# Altium schematic sheet Power over Ethernet.SchDoc
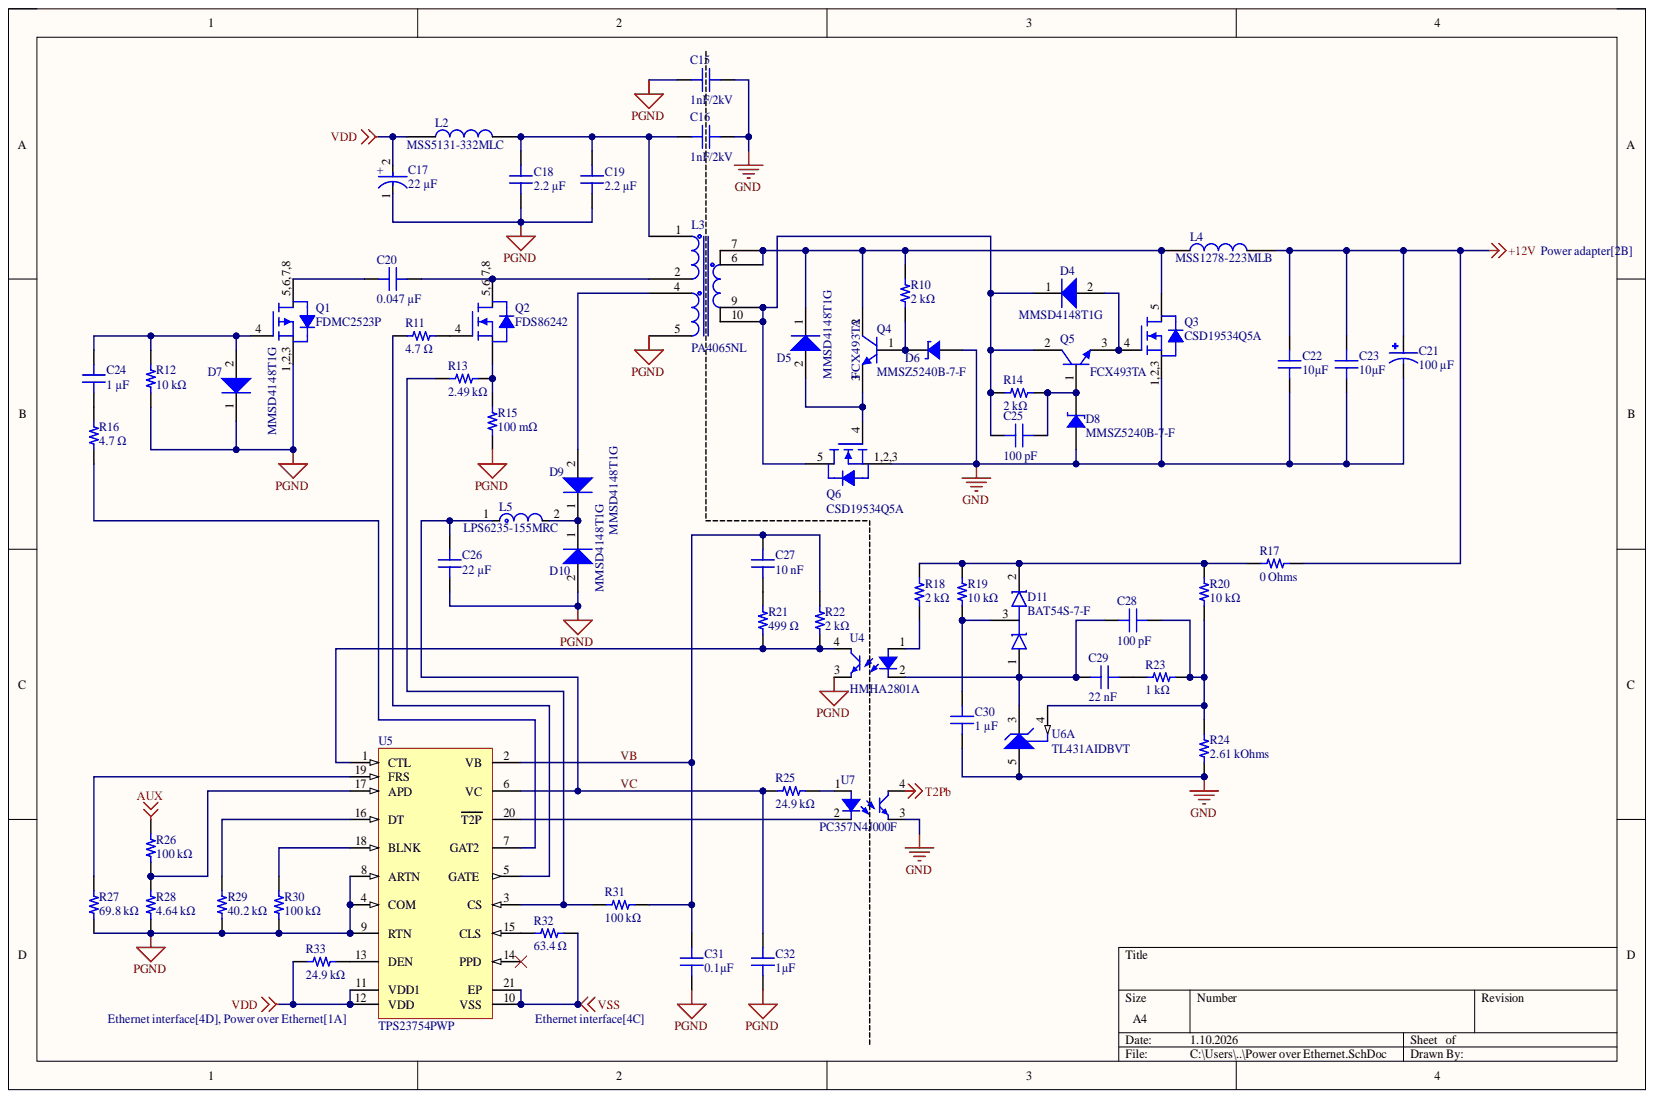
[diagram p0: Power over Ethernet.SchDoc]

## Parts
C15  1nF/2kV  [CAP 1206_3216 - 0.8MM]
C16  1nF/2kV  [CAP 1206_3216 - 0.8MM]
C17  22 µF  [FP-FKE-MFG]
C18  2.2 µF  [CAP 1210_3225 - 1MM]
C19  2.2 µF  [CAP 1210_3225 - 1MM]
C20  0.047 µF  [CAP 1206_3216 - 0.8MM]
C21  100 µF  [CAP_EEEFC1E101P]
C22  10µF  [CAP 1210_3225 - 2.8MM]
C23  10µF  [CAP 1210_3225 - 2.8MM]
C24  1 µF  [CAP 0805_2012]
C25  100 pF  [CAP 0603_1608]
C26  22 µF  [CAP 1210_3225 - 1MM]
C27  Capacitance: 10 nF  [CAP 0603_1608]  (hidden comment: 10000 pF)
C28  100 pF  [CAP 0603_1608]
C29  Capacitance: 22 nF  [CAP 0603_1608]  (hidden comment: 0.022 µF)
C30  1 µF  [CAP 0805_2012]
C31  0.1µF  [CAP 0805_2012]
C32  1µF  [CAP 0805_2012]
D4  MMSD4148T1G  [ONSC-SOD-123-2-425-04_V]
D5  MMSD4148T1G  [ONSC-SOD-123-2-425-04_V]
D6  MMSZ5240B-7-F  [SOD-123]
D7  MMSD4148T1G  [ONSC-SOD-123-2-425-04_V]
D8  MMSZ5240B-7-F  [SOD-123]
D9  MMSD4148T1G  [ONSC-SOD-123-2-425-04_V]
D10  MMSD4148T1G  [ONSC-SOD-123-2-425-04_V]
D11  BAT54S-7-F  [SOT96P240X115-3N]
L2  MSS5131-332MLC  [MSS5131332MLC]
L3  PA4065NL  [PA4065NL]
L4  MSS1278-223MLB  [INDPM120120X805N]
L5  LPS6235-155MRC  [FP-LPS6235-MFG]
Q1  FDMC2523P  [FDMC_2523P_ONS]
Q2  FDS86242  [SOIC127P600X175-8N]
Q3  CSD19534Q5A  [TRANS_CSD19534Q5A]
Q4  FCX493TA  [FCX493TA_DIO]
Q5  FCX493TA  [FCX493TA_DIO]
Q6  CSD19534Q5A  [TRANS_CSD19534Q5A]
R10  2 kΩ  [RES 1206_3216]
R11  4.7 Ω  [RES 0603_1608]
R12  10 kΩ  [RES 0603_1608]
R13  2.49 kΩ  [RES 0603_1608]
R14  2 kΩ  [RES 1206_3216]
R15  100 mΩ  [RES 1210_3225]
R16  4.7 Ω  [RES 0603_1608]
R17  0 Ohms  [RES 0603_1608]
R18  2 kΩ  [RES 0603_1608]
R19  10 kΩ  [RES 0603_1608]
R20  10 kΩ  [RES 0603_1608]
R21  499 Ω  [RES 0603_1608]
R22  2 kΩ  [RES 0603_1608]
R23  1 kΩ  [RES 0603_1608]
R24  2.61 kOhms  [RES 0603_1608]
R25  24.9 kΩ  [RES 0603_1608]
R26  100 kΩ  [RES 0603_1608]
R27  69.8 kΩ  [RES 0603_1608]
R28  4.64 kΩ  [YAGEO RES 0603_1608]
R29  40.2 kΩ  [YAGEO RES 0603_1608]
R30  100 kΩ  [RES 0603_1608]
R31  100 kΩ  [RES 0603_1608]
R32  63.4 Ω  [KOA RES RK73 RN73 0603_1608]
R33  24.9 kΩ  [RES 0603_1608]
U4  HMHA2801A  [SOIC127P680X239-4N]
U5  TPS23754PWP  [PWP0020D_M]
U6  TL431AIDBVT  [DBV0005A_N]  (part A)
U7  PC357N4J000F  [SOP254P675X300-4N]

## Nets
+12V: C21.1 C22.2 C23.2 L4.2 R17.2
AUX: R26.2
GND: C15.1 C16.1 C21.2 C22.1 C23.1 C30.1 D6.1 D8.1 Q3.1,2,3 Q6.1,2,3 R24.1 U6.5 U7.3
PGND: C15.2 C17.1 C18.1 C19.1 C26.1 C31.1 C32.2 D7.1 D10.2 L3.5 Q1.1,2,3 R12.1 R15.1 R27.1 R28.1 R29.1 R30.1 U4.3 U5.4(COM) U5.8(ARTN) U5.9(RTN)
T2Pb: U7.4
VDD: C17.2 L2.1 R33.1 U5.11(VDD1) U5.12(VDD)
VSS: R32.1 U5.10(VSS) U5.21(EP)
VB: C27.1 C31.2 R22.1 R31.2 U5.2(VB)
VC: C26.2 C32.1 L5.1 R25.1 U5.6(VC)
NetC16_2: C16.2 C18.2 C19.2 L2.2 L3.1
NetC20_1: C20.1 Q1.5,6,7,8
NetC20_2: C20.2 L3.2 Q2.5,6,7,8
NetC24_1: C24.1 R16.2
NetC24_2: C24.2 D7.2 Q1.4 R12.2
NetC25_1: C25.1 D4.1 L3.9 L3.10 Q5.2 Q6.5 R14.2
NetC25_2: C25.2 D8.2 Q5.1 R14.1
NetC27_2: C27.2 R21.1
NetC28_1: C28.1 C29.1 D11.1 U4.2 U6.3
NetC28_2: C28.2 R20.1 R23.2 R24.2 U6.4
NetC29_2: C29.2 R23.1
NetC30_2: C30.2 D11.3 R19.1
NetD4_2: D4.2 Q3.4 Q5.3
NetD5_1: D5.1 L3.6 L3.7 L4.1 Q3.5 Q4.2 R10.2
NetD5_2: D5.2 Q4.3 Q6.4
NetD6_2: D6.2 Q4.1 R10.1
NetD9_1: D9.1 D10.1 L5.2
NetD9_2: D9.2 L3.4
NetD11_2: D11.2 R17.1 R18.1 R19.2 R20.2
NetQ2_1,2,3: Q2.1,2,3 R13.2 R15.2
NetQ2_4: Q2.4 R11.2
NetR11_1: R11.1 U5.5(GATE)
NetR13_1: R13.1 R31.1 U5.3(CS)
NetR16_1: R16.1 U5.7(GAT2)
NetR18_2: R18.2 U4.1
NetR21_2: R21.2 R22.2 U4.4 U5.1(CTL)
NetR25_2: R25.2 U7.1
NetR26_1: R26.1 R28.2 U5.17(APD)
NetR27_2: R27.2 U5.19(FRS)
NetR29_2: R29.2 U5.16(DT)
NetR30_2: R30.2 U5.18(BLNK)
NetR32_2: R32.2 U5.15(CLS)
NetR33_2: R33.2 U5.13(DEN)
NetU5_20: U5.20(T2P) U7.2

Not connected: U5 14(PPD)

## Library data of the parts
C15 C16 = 1206B102K202NT: CAP CER 1000PF 2KV X7R 1206; Database Table Name: Capacitors - Ceramic - 1206; Capacitance: 1000 pF; Component Kind: Standard; Library Name: Celestial Altium Library.DbLib; Part Number: 1206B102K202NT; Supplier 1: Arrow Electronics; Supplier Part Number 1: 1206B102K202NT
C17 = CMP-05427-002164-1: CAP ALUM 22UF 20% 63V SMD; Dielectric Material: Aluminium; ESR (Equivalent Series Resistance): 1.2R; Leakage Current: 3uA; Life (Hours): 2000Hour; Ripple Current: 120mA; Capacitance: 22 uF; Case/Package: Radial; Component Kind: Standard; Construction: Cylindrical; Contact Plating: Tin; Diameter: 8mm; Height: 6.2mm; Height - Seated (Max): 0.244inch; Impedance: 1.2R; Lead Free: Lead Free; Length: 6.2mm; Library Name: Altium Content Vault; Material: Aluminium; Max Operating Temperature: 105°C; Min Operating Temperature: -55°C; Mount: Surface Mount; Package Quantity: 1000; Packaging: Tape and Reel; Radiation Hardening: No; Ratings: AEC-Q200; Reach SVHC Compliant: Yes; Ripple Current (AC): 120mA; RoHS Compliant: Yes; Series: FK; Size: 6.2mm; Termination: SMD/SMT; Tolerance: 20%; Value: 22 µF; Voltage: 63V; Voltage Rating: 63V; Voltage Rating (DC): 63V; Width: 8.3mm
C18 C19 = CL32B225KCJSNNE: CAP CER 2.2UF 100V X7R 1210; manufacturer: Samsung Electro-Mechanics; Database Table Name: Capacitors - Ceramic - 1210; Tolerance: ±10%; Applications: General Purpose; Capacitance: 2.2 µF; Component Kind: Standard; componentlink1description: Datasheet; componentlink1url: http://www.samsungsem.com/kr/support/product-search/mlcc/CL32B225KCJSNNE .jsp; componentlink2description: DigiKey Link; componentlink2url: https://www.digikey.com/en/products/detail/samsung-electro-mechanics/CL32B225KCJSNNE/3889020; Device Package: 1210 (3225 Metric); Features: Soft Termination; lastupdated: 4.06.2024 19:20:59; Library Name: Celestial Altium Library - altium_library.DbLib; Lifecycle Status: Active; Minimum Order: 1; Mounting Type: Surface Mount, MLCC; Operating Temperature: -55°C ~ 125°C; Package / Case: 1210 (3225 Metric); packaging: TapeAndReel; Part Number: CL32B225KCJSNNE; Part Status: Active; partid: 24106; price: 0.09; series: CL; Size / Dimension: 0.126" L x 0.098" W (3.20mm x 2.50mm); Supplier 1: DigiKey; Supplier Part Number 1: 1276-3362-1-ND; Temperature Coefficient: X7R; Thickness (Max): 0.106" (2.70mm); Value: 2.2 µF; Voltage - Rated: 100V
C20 = C3216X7R2E473K160AA: CAP CER 0.047UF 250V X7R 1206; manufacturer: TDK Corporation; Database Table Name: Capacitors - Ceramic - 1206; Tolerance: ±10%; Applications: General Purpose; Capacitance: 0.047 µF; Component Kind: Standard; componentlink1description: Datasheet; componentlink1url: https://product.tdk.com/info/en/catalog/datasheets/mlcc_commercial_midvoltage_en.pdf?ref_disty=digikey; componentlink2description: DigiKey Link; componentlink2url: https://www.digikey.com/en/products/detail/tdk-corporation/C3216X7R2E473K160AA/789680; Device Package: 1206 (3216 Metric); lastupdated: 4.06.2024 19:10:39; Library Name: Celestial Altium Library - altium_library.DbLib; Lifecycle Status: Active; Minimum Order: 1; Mounting Type: Surface Mount, MLCC; Operating Temperature: -55°C ~ 125°C; Package / Case: 1206 (3216 Metric); packaging: TapeAndReel; Part Number: C3216X7R2E473K160AA; Part Status: Active; partid: 20477; price: 0.05; series: C; Size / Dimension: 0.126" L x 0.063" W (3.20mm x 1.60mm); Supplier 1: DigiKey; Supplier Part Number 1: 445-2286-1-ND; Temperature Coefficient: X7R; Thickness (Max): 0.071" (1.80mm); value: 0.047 µF; Voltage - Rated: 250V
C21 = EEEFC1E101P: Capacitor,Alum Electrolytic,Cap 100 uF,Tol 20%,Vol-Rtg 25 VDC,SMT,8x10.2,TR | Panasonic Electronic Components EEE-FC1E101P; Check_prices: https://www.snapeda.com/parts/EEE-FC1E101P/Panasonic+Electronic+Components/view-part/?ref=eda; Component Kind: Standard; Library Name: SchLib.SchLib; MF: Panasonic; MP: EEE-FC1E101P; Package: RADIAL-2 Panasonic; SnapEDA_Link: https://www.snapeda.com/parts/EEE-FC1E101P/Panasonic+Electronic+Components/view-part/?ref=snap; Value: 100 µF
C22 C23 = 12103C106K4T2A: CAP CER 10UF 25V X7R 1210; manufacturer: AVX Corporation; Database Table Name: Capacitors - Ceramic - 1210; Tolerance: ±10%; Applications: Automotive; Capacitance: 10µF; Component Kind: Standard; componentlink1description: Datasheet; componentlink1url: http://datasheets.avx.com/AutoMLCC.pdf; componentlink2description: DigiKey Link; componentlink2url: http://digikey.com/product-detail/en/avx-corporation/12103C106K4T2A/478-6128-1-ND/2295047; Device Package: 1210 (3225 Metric); lastupdated: 2.09.2020 01:10:50; Library Name: Celestial Altium Library - altium_library.DbLib; Lifecycle Status: Active; Minimum Order: 1; Mounting Type: Surface Mount, MLCC; Operating Temperature: -55°C ~ 125°C; Package / Case: 1210 (3225 Metric); packaging: TapeAndReel; Part Number: 12103C106K4T2A; Part Status: Active; partid: 24004; price: 0.31; Ratings: AEC-Q200; series: -; Size / Dimension: 0.126" L x 0.098" W (3.20mm x 2.50mm); Supplier 1: DigiKey; Supplier Part Number 1: 478-6128-1-ND; Temperature Coefficient: X7R; Thickness (Max): 0.110" (2.79mm); Value: 10µF; Voltage - Rated: 25V
C24 C30 = C2012X7R1E105K125AB: CAP CER 1UF 25V X7R 0805; manufacturer: TDK Corporation; Database Table Name: Capacitors - Ceramic - 0805; Tolerance: ±10%; Applications: General Purpose; Capacitance: 1 µF; Component Kind: Standard; componentlink1description: Datasheet; componentlink1url: https://product.tdk.com/info/en/catalog/datasheets/mlcc_commercial_general_en.pdf?ref_disty=digikey; componentlink2description: DigiKey Link; componentlink2url: https://www.digikey.com/en/products/detail/tdk-corporation/C2012X7R1E105K125AB/513887; Device Package: 0805 (2012 Metric); Features: Low ESL; lastupdated: 9.02.2024 20:15:16; Library Name: Celestial Altium Library - altium_library.DbLib; Lifecycle Status: Active; Minimum Order: 1; Mounting Type: Surface Mount, MLCC; Operating Temperature: -55°C ~ 125°C; Package / Case: 0805 (2012 Metric); packaging: TapeAndReel; Part Number: C2012X7R1E105K125AB; Part Status: Active; partid: 33961; price: 0.03; series: C; Size / Dimension: 0.079" L x 0.049" W (2.00mm x 1.25mm); Supplier 1: DigiKey; Supplier Part Number 1: 445-1354-1-ND; Temperature Coefficient: X7R; Thickness (Max): 0.057" (1.45mm); value: 1 µF; Voltage - Rated: 25V
C25 C28 = C1608C0G1H101J080AE: CAP CER 100PF 50V NP0 0603; manufacturer: TDK Corporation; Database Table Name: Capacitors - Ceramic - 0603; Temperature Coefficient: C0G, NP0; Tolerance: ±5%; Applications: Boardflex Sensitive; Capacitance: 100 pF; Component Kind: Standard; componentlink1description: Datasheet; componentlink1url: https://product.tdk.com/info/en/catalog/datasheets/mlcc_commercial_soft_en.pdf?ref_disty=digikey; componentlink2description: DigiKey Link; componentlink2url: https://www.digikey.com/en/products/detail/tdk-corporation/C1608C0G1H101J080AE/5809176; Device Package: 0603 (1608 Metric); Features: Soft Termination; lastupdated: 9.02.2024 20:10:12; Library Name: Celestial Altium Library - altium_library.DbLib; Lifecycle Status: Active; Minimum Order: 1; Mounting Type: Surface Mount, MLCC; Operating Temperature: -55°C ~ 125°C; Package / Case: 0603 (1608 Metric); packaging: TapeAndReel; Part Number: C1608C0G1H101J080AE; Part Status: Active; partid: 15324; price: 0.01; series: C; Size / Dimension: 0.063" L x 0.031" W (1.60mm x 0.80mm); Supplier 1: DigiKey; Supplier Part Number 1: 445-173611-1-ND; Thickness (Max): 0.035" (0.90mm); value: 100 pF; Voltage - Rated: 50V
C26 = CL32B226KAJNNWE: CAP CER 22UF 25V X7R 1210; manufacturer: Samsung Electro-Mechanics; Database Table Name: Capacitors - Ceramic - 1210; Tolerance: ±10%; Applications: General Purpose; Capacitance: 22 µF; Component Kind: Standard; componentlink1description: Datasheet; componentlink1url: http://www.samsungsem.com/kr/support/product-search/mlcc/CL32B226KAJNNWE.jsp; componentlink2description: DigiKey Link; componentlink2url: https://www.digikey.com/en/products/detail/samsung-electro-mechanics/CL32B226KAJNNWE/3889051; Device Package: 1210 (3225 Metric); lastupdated: 4.06.2024 19:21:03; Library Name: Celestial Altium Library - altium_library.DbLib; Lifecycle Status: Active; Minimum Order: 1; Mounting Type: Surface Mount, MLCC; Operating Temperature: -55°C ~ 125°C; Package / Case: 1210 (3225 Metric); packaging: TapeAndReel; Part Number: CL32B226KAJNNWE; Part Status: Active; partid: 23847; price: 0.32; series: CL; Size / Dimension: 0.126" L x 0.098" W (3.20mm x 2.50mm); Supplier 1: DigiKey; Supplier Part Number 1: 1276-3393-1-ND; Temperature Coefficient: X7R; Thickness (Max): 0.106" (2.70mm); Value: 22 µF; Voltage - Rated: 25V
C27 = C1608X7R1H103K080AE: CAP CER 10000PF 50V X7R 0603; manufacturer: TDK Corporation; Database Table Name: Capacitors - Ceramic - 0603; Tolerance: ±10%; Applications: Boardflex Sensitive; Component Kind: Standard; componentlink1description: Datasheet; componentlink1url: https://product.tdk.com/info/en/catalog/datasheets/mlcc_commercial_soft_en.pdf?ref_disty=digikey; componentlink2description: DigiKey Link; componentlink2url: https://www.digikey.com/en/products/detail/tdk-corporation/C1608X7R1H103K080AE/4490562; Device Package: 0603 (1608 Metric); Features: Soft Termination; lastupdated: 9.02.2024 20:10:18; Library Name: Celestial Altium Library - altium_library.DbLib; Lifecycle Status: Active; Minimum Order: 1; Mounting Type: Surface Mount, MLCC; Operating Temperature: -55°C ~ 125°C; Package / Case: 0603 (1608 Metric); packaging: TapeAndReel; Part Number: C1608X7R1H103K080AE; Part Status: Active; partid: 11552; price: 0.02; series: C; Size / Dimension: 0.063" L x 0.031" W (1.60mm x 0.80mm); Supplier 1: DigiKey; Supplier Part Number 1: 445-15925-1-ND; Temperature Coefficient: X7R; Thickness (Max): 0.037" (0.95mm); value: 10000 pF; Voltage - Rated: 50V
C29 = C1608X7R1H223K080AE: CAP CER 0.022UF 50V X7R 0603; manufacturer: TDK Corporation; Database Table Name: Capacitors - Ceramic - 0603; Tolerance: ±10%; Applications: Boardflex Sensitive; Component Kind: Standard; componentlink1description: Datasheet; componentlink1url: https://product.tdk.com/info/en/catalog/datasheets/mlcc_commercial_soft_en.pdf?ref_disty=digikey; componentlink2description: DigiKey Link; componentlink2url: https://www.digikey.com/en/products/detail/tdk-corporation/C1608X7R1H223K080AE/5809178; Device Package: 0603 (1608 Metric); Features: Soft Termination; lastupdated: 9.02.2024 20:10:18; Library Name: Celestial Altium Library - altium_library.DbLib; Lifecycle Status: Active; Minimum Order: 1; Mounting Type: Surface Mount, MLCC; Operating Temperature: -55°C ~ 125°C; Package / Case: 0603 (1608 Metric); packaging: TapeAndReel; Part Number: C1608X7R1H223K080AE; Part Status: Active; partid: 11617; price: 0.02; series: C; Size / Dimension: 0.063" L x 0.031" W (1.60mm x 0.80mm); Supplier 1: DigiKey; Supplier Part Number 1: 445-173613-1-ND; Temperature Coefficient: X7R; Thickness (Max): 0.035" (0.90mm); value: 0.022 µF; Voltage - Rated: 50V
C31 = 08051C104KAT2A: CAP CER 0.1UF 100V X7R 0805; Database Table Name: Capacitors - Ceramic - 0805; Capacitance: 0.1µF; Component Kind: Standard; Library Name: Celestial Altium Library.DbLib; Part Number: 08051C104KAT2A
C32 = 08053C105MAT4A: CAP CER 1UF 25V X7R 0805; manufacturer: AVX Corporation; Database Table Name: Capacitors - Ceramic - 0805; Tolerance: ±20%; Applications: General Purpose; Capacitance: 1µF; Component Kind: Standard; componentlink1description: Datasheet; componentlink1url: http://datasheets.avx.com/X7RDielectric.pdf; componentlink2description: DigiKey Link; componentlink2url: http://digikey.com/product-detail/en/avx-corporation/08053C105MAT4A/478-11517-1-ND/8107718; Device Package: 0805 (2012 Metric); lastupdated: 2.09.2020 01:04:40; Library Name: Celestial Altium Library - altium_library.DbLib; Lifecycle Status: Active; Minimum Order: 1; Mounting Type: Surface Mount, MLCC; Operating Temperature: -55°C ~ 125°C; Package / Case: 0805 (2012 Metric); packaging: TapeAndReel; Part Number: 08053C105MAT4A; Part Status: Active; partid: 3658; price: 0.04; series: -; Size / Dimension: 0.079" L x 0.049" W (2.01mm x 1.25mm); Supplier 1: DigiKey; Supplier Part Number 1: 478-11517-1-ND; Temperature Coefficient: X7R; Thickness (Max): 0.055" (1.40mm); value: 1µF; Voltage - Rated: 25V
D4 D5 D7 D9 D10 = CMP-0895-00001-2: Switching Diode, 2-Pin SOD-123, Pb-Free, Tape and Reel; Manufacturer: On Semiconductor; Configuration: Single; IF Max (A): 0.2; trr Max (ns): 4; Component Kind: Standard; ComponentLink1Description: Manufacturer URL; ComponentLink1URL: http://www.onsemi.com/; ComponentLink2Description: Datasheet; ComponentLink2URL: http://www.onsemi.com/pub_link/Collateral/MMSD4148T1-D.PDF; ComponentLink3Description: Package Specification; ComponentLink3URL: http://www.onsemi.com/pub_link/Collateral/425-04.PDF; DatasheetVersion: Rev. 11; Library Name: Altium Content Vault; Mounting Technology: Surface Mount; PackageDescription: 2-Pin SOD, Body 1.6 x 2.69 mm; PackageReference: SOD-123-2-425-04; PackageVersion: Rev. G, 10/2009; Packing: Tape and Reel; PartNumber: MMSD4148T1G; RoHS: TRUE
D6 D8 = MMSZ5240B-7-F: DIODE ZENER 10V 500MW SOD123; Manufacturer: Diodes Incorporated; Current - Reverse Leakage @ Vr: 3 µA @ 8 V; Database Table Name: Diodes - Zener - Single; Impedance (Max) (Zzt): 17 Ohms; Tolerance: ±5%; Voltage - Forward (Vf) (Max) @ If: 900 mV @ 10 mA; Component Kind: Standard; ComponentLink1Description: Datasheet; ComponentLink1URL: https://www.diodes.com/assets/Datasheets/ds18010.pdf; ComponentLink2Description: DigiKey Link; ComponentLink2URL: https://www.digikey.com/en/products/detail/diodes-incorporated/MMSZ5240B-7-F/814369; LastUpdated: 3.06.2024 19:55:44; Library Name: Celestial Altium Library - altium_library.DbLib; Lifecycle Status: Active; Minimum Order: 1; Mounting Type: Surface Mount; Operating Temperature: -65°C ~ 150°C; Package / Case: SOD-123; Packaging: TapeAndReel; Part Number: MMSZ5240B-7-F; Part Status: Active; PartId: 2232; Power - Max: 500 mW; Price: 0.02; Series: -; Supplier 1: DigiKey; Supplier Device Package: SOD-123; Supplier Part Number 1: MMSZ5240B-FDICT-ND; Voltage - Zener (Nom) (Vz): 10 V
D11 = BAT54S-7-F: Schottky Diode 2xSeries 200mA 30V SOT23 Diodes Inc BAT54S-7-F, Dual SMT Schottky Switching Diode, Series, 30V 200mA, 5ns, 3-Pin SOT-23; Manufacturer_Name: Diodes Incorporated; Geometry.Height: 1.15mm; Arrow Part Number: BAT54S-7-F; Arrow Price/Stock: https://www.arrow.com/en/products/bat54s-7-f/diodes-incorporated?utm_currency=USD&region=nac; Component Kind: Standard; Datasheet Link: https://www.diodes.com//assets/Datasheets/BAT54_A_C_S.pdf; Library Name: SchLib.SchLib; Manufacturer_Part_Number: BAT54S-7-F; Mouser Part Number: 621-BAT54S-F; Mouser Price/Stock: https://www.mouser.co.uk/ProductDetail/Diodes-Incorporated/BAT54S-7-F?qs=SrifhsLOLf4kNGw4QqCzrA%3D%3D
L2 = MSS5131-332MLC: Fixed Inductors 33uH Shld 20% 2.9A 28mOhms AECQ2; Manufacturer_Name: COILCRAFT; Geometry.Height: 3.1mm; Component Kind: Standard; Datasheet Link: https://componentsearchengine.com/Datasheets/1/MSS5131-332MLC.pdf; Library Name: SchLib.SchLib; Manufacturer_Part_Number: MSS5131-332MLC; Mouser Part Number: 994-MSS5131-332MLC; Mouser Price/Stock: https://www.mouser.co.uk/ProductDetail/Coilcraft/MSS5131-332MLC?qs=zCSbvcPd3pZLAvWDDWFtVA%3D%3D
L3 = PA4065NL: Switching Transformer 1.58:1:1 0.075Ohm/0.41Ohm Prim. DCR 0.04Ohm Sec. DCR 10Term. Gull Wing SMD; Manufacturer_Name: PULSE; Geometry.Height: 12.32mm; Arrow Part Number: PA4065NL; Arrow Price/Stock: https://www.arrow.com/en/products/pa4065nl/pulse-electronics-corporation; Component Kind: Standard; Datasheet Link: https://www.mouser.de/datasheet/2/447/P675-2903965.pdf; Library Name: SchLib.SchLib; Manufacturer_Part_Number: PA4065NL; Mouser Part Number: N/A; Mouser Price/Stock: https://www.mouser.com/Search/Refine.aspx?Keyword=N%2FA
L4 = MSS1278-223MLB: Fixed Inductors MSS1278 AEC-Q200 22 uH 20% 4A Ferrite; Manufacturer_Name: COILCRAFT; Geometry.Height: 8.05mm; Component Kind: Standard; Datasheet Link: https://www.coilcraft.com/pdfs/mss1278.pdf; Library Name: SchLib.SchLib; Manufacturer_Part_Number: MSS1278-223MLB; Mouser Part Number: 994-MSS1278-223MLB; Mouser Price/Stock: https://www.mouser.co.uk/ProductDetail/Coilcraft/MSS1278-223MLB?qs=VJjuEbE9QBPONvxxp7IxZg%3D%3D
L5 = CMP-01768-30061421-1: LPS6235-155MRC Power inductor, shielded, 20% tol, SMT, RoHS, lead-free, halogen-free; Core Material: Ferrite; Inductance: 1.5mH; RMS Current (Irms): 260mA; Saturation Current: 120mA; Self Resonant Frequency: 1.9MHz; Series Resistance: 4.6Ω; Test Frequency: 100kHz; Ambient Temperature Range High: 85°C; Component Kind: Standard; Composition: Wirewound; Height: 3.5mm; Library Name: Altium Content Vault; Lifecycle Status: Production; NexarPartId: 30061421; RoHS: Non-Compliant; Tolerance: 20%
Q1 = FDMC2523P: P-Channel 150 V 3A (Tc) 42W (Tc) Surface Mount 8-MLP (3.3x3.3); Manufacturer_Name: onsemi; Component Kind: Standard; Library Name: SchLib.SchLib; Manufacturer_Part_Number: FDMC2523P; RefDes: RefDes; Type: Type; Copyright: Copyright (C) 2025 Ultra Librarian. All rights reserved.
Q2 = FDS86242: 100% UIL Tested; Max rDS(on) = 67 m at VGS = 10 V, ID = 4.1 A; High performance trench technology for extremely low rDS(on); Max rDS(on) = 98m at VGS = 6 V, ID = 3.3 A; RoHS Compliant; High power and current handling capability in a widely usedsurface mount package; Manufacturer_Name: onsemi; Geometry.Height: 1.75mm; Arrow Part Number: FDS86242; Arrow Price/Stock: https://www.arrow.com/en/products/fds86242/on-semiconductor; Component Kind: Standard; Datasheet Link: https://www.onsemi.com/pub/Collateral/FDS86242-D.PDF; Library Name: SchLib.SchLib; Manufacturer_Part_Number: FDS86242; Mouser Part Number: 512-FDS86242; Mouser Price/Stock: https://www.mouser.co.uk/ProductDetail/ON-Semiconductor-Fairchild/FDS86242?qs=WZYOKEqGBpJo60pi1Jf2hA%3D%3D
Q3 Q6 = CSD19534Q5A: 100V, N ch NexFET MOSFET, single SON5x6, 15.1mOhm 8-VSONP -55 to 150; MF: Texas Instruments; Availability: Good; Component Kind: Standard; DigiKey-Purchase-URL: https://snapeda.com/shop?store=DigiKey&id=485742; Library Name: SchLib.SchLib; Mouser-Purchase-URL: https://snapeda.com/shop?store=Mouser&id=485742; MP: CSD19534Q5A; Package: VSONP-8 Texas Instruments; Price: None; SnapEDA_Link: https://www.snapeda.com/parts/CSD19534Q5A/Texas%20Instruments/view-part/485742/?ref=snap; Texas_Instruments-Purchase-URL: https://snapeda.com/shop?store=Texas+Instruments&id=485742
Q4 Q5 = FCX493TA: Description; Manufacturer_Name: Diodes Inc; Component Kind: Standard; Library Name: SchLib.SchLib; Manufacturer_Part_Number: FCX493TA; RefDes: RefDes; Type: Type; Copyright: Copyright (C) 2025 Ultra Librarian. All rights reserved.
R10 R14 = CRCW12062K00JNEA: RES SMD 2K OHM 5% 1/4W 1206; Manufacturer: Vishay Dale; Database Table Name: Resistors - Surface Mount - 1206; Power (Watts): 0.25W, 1/4W; Temperature Coefficient: ±200ppm/°C; Component Kind: Standard; ComponentLink1Description: Datasheet; ComponentLink1URL: http://www.vishay.com/docs/20035/dcrcwe3.pdf; ComponentLink2Description: DigiKey Link; ComponentLink2URL: https://www.digikey.com/en/products/detail/vishay-dale/CRCW12062K00JNEA/1176212; Composition: Thick Film; Device Package: 1206 (3216 Metric); Features: Automotive AEC-Q200; Height - Seated (Max): 0.024" (0.60mm); LastUpdated: 9.02.2024 20:54:08; Library Name: Celestial Altium Library - altium_library.DbLib; Lifecycle Status: Active; Minimum Order: 1; Number of Terminations: 2; Operating Temperature: -55°C ~ 155°C; Package / Case: 1206 (3216 Metric); Packaging: TapeAndReel; Part Number: CRCW12062K00JNEA; Part Status: Active; PartId: 36387; Price: 0.01; Ratings: AEC-Q200; Resistance: 2 kΩ; Series: CRCW; Size / Dimension: 0.126" L x 0.063" W (3.20mm x 1.60mm); Supplier 1: DigiKey; Supplier Device Package: 1206; Supplier Part Number 1: 541-2.0KECT-ND; Tolerance: ±5%; Value: 2 kΩ
R11 R16 = CRCW06034R70JNEA: RES SMD 4.7 OHM 5% 1/10W 0603; Manufacturer: Vishay Dale; Database Table Name: Resistors - Surface Mount - 0603; Power (Watts): 0.1W, 1/10W; Resistance: 4.7 Ohms; Temperature Coefficient: ±200ppm/°C; Component Kind: Standard; ComponentLink1Description: Datasheet; ComponentLink1URL: http://www.vishay.com/docs/20035/dcrcwe3.pdf; ComponentLink2Description: DigiKey Link; ComponentLink2URL: https://www.digikey.com/en/products/detail/vishay-dale/CRCW06034R70JNEA/1174173; Composition: Thick Film; Device Package: 0603 (1608 Metric); Features: Automotive AEC-Q200; Height - Seated (Max): 0.020" (0.50mm); LastUpdated: 9.02.2024 20:42:33; Library Name: Celestial Altium Library - altium_library.DbLib; Lifecycle Status: Active; Minimum Order: 1; Number of Terminations: 2; Operating Temperature: -55°C ~ 155°C; Package / Case: 0603 (1608 Metric); Packaging: TapeAndReel; Part Number: CRCW06034R70JNEA; Part Status: Active; PartId: 21221; Price: 0.01; Ratings: AEC-Q200; Series: CRCW; Size / Dimension: 0.063" L x 0.033" W (1.60mm x 0.85mm); Supplier 1: DigiKey; Supplier Device Package: 0603; Supplier Part Number 1: 541-4.7GCT-ND; Tolerance: ±5%; Value: 4.7 Ω
R12 R19 R20 = CRCW060310K0FKEA: RES SMD 10K OHM 1% 1/10W 0603; Manufacturer: Vishay Dale; Database Table Name: Resistors - Surface Mount - 0603; Power (Watts): 0.1W, 1/10W; Resistance: 10 kOhms; Temperature Coefficient: ±100ppm/°C; Component Kind: Standard; ComponentLink1Description: Datasheet; ComponentLink1URL: http://www.vishay.com/docs/20035/dcrcwe3.pdf; ComponentLink2Description: DigiKey Link; ComponentLink2URL: https://www.digikey.com/en/products/detail/vishay-dale/CRCW060310K0FKEA/1174782; Composition: Thick Film; Device Package: 0603 (1608 Metric); Features: Automotive AEC-Q200; Height - Seated (Max): 0.020" (0.50mm); LastUpdated: 9.02.2024 20:42:10; Library Name: Celestial Altium Library - altium_library.DbLib; Lifecycle Status: Active; Minimum Order: 1; Number of Terminations: 2; Operating Temperature: -55°C ~ 155°C; Package / Case: 0603 (1608 Metric); Packaging: CutTape; Part Number: CRCW060310K0FKEA; Part Status: Active; PartId: 24636; Price: 0.01; Ratings: AEC-Q200; Series: CRCW; Size / Dimension: 0.061" L x 0.033" W (1.55mm x 0.85mm); Supplier 1: DigiKey; Supplier Device Package: 0603; Supplier Part Number 1: 541-10.0KHCT-ND; Tolerance: ±1%; Value: 10 kΩ
R13 = CRCW06032K49FKEA: RES SMD 2.49K OHM 1% 1/10W 0603; Manufacturer: Vishay Dale; Database Table Name: Resistors - Surface Mount - 0603; Power (Watts): 0.1W, 1/10W; Resistance: 2.49 kOhms; Temperature Coefficient: ±100ppm/°C; Component Kind: Standard; ComponentLink1Description: Datasheet; ComponentLink1URL: http://www.vishay.com/docs/20035/dcrcwe3.pdf; ComponentLink2Description: DigiKey Link; ComponentLink2URL: https://www.digikey.com/en/products/detail/vishay-dale/CRCW06032K49FKEA/1174711; Composition: Thick Film; Device Package: 0603 (1608 Metric); Features: Automotive AEC-Q200; Height - Seated (Max): 0.020" (0.50mm); LastUpdated: 9.02.2024 20:42:28; Library Name: Celestial Altium Library - altium_library.DbLib; Lifecycle Status: Active; Minimum Order: 1; Number of Terminations: 2; Operating Temperature: -55°C ~ 155°C; Package / Case: 0603 (1608 Metric); Packaging: TapeAndReel; Part Number: CRCW06032K49FKEA; Part Status: Active; PartId: 22463; Price: 0.01; Ratings: AEC-Q200; Series: CRCW; Size / Dimension: 0.061" L x 0.033" W (1.55mm x 0.85mm); Supplier 1: DigiKey; Supplier Device Package: 0603; Supplier Part Number 1: 541-2.49KHCT-ND; Tolerance: ±1%; Value: 2.49 kΩ
R15 = RL1210JR-070R1L: RES 0.1 OHM 5% 1/2W 1210; Manufacturer: Yageo; Database Table Name: Resistors - Surface Mount - 1210; Power (Watts): 0.5W, 1/2W; Temperature Coefficient: ±600ppm/°C; Component Kind: Standard; ComponentLink1Description: Datasheet; ComponentLink1URL: http://www.yageo.com/documents/recent/PYu-RL_Group_521_RoHS_L_2.pdf; ComponentLink2Description: DigiKey Link; ComponentLink2URL: https://www.digikey.com/en/products/detail/yageo/RL1210JR-070R1L/3886421; Composition: Thick Film; Device Package: 1210 (3225 Metric); Features: Automotive AEC-Q200, Current Sense, Moisture Resistant; Height - Seated (Max): 0.026" (0.65mm); LastUpdated: 3.06.2024 20:19:08; Library Name: Celestial Altium Library - altium_library.DbLib; Lifecycle Status: Active; Minimum Order: 1; Number of Terminations: 2; Operating Temperature: -55°C ~ 155°C; Package / Case: 1210 (3225 Metric); Packaging: TapeAndReel; Part Number: RL1210JR-070R1L; Part Status: Active; Price: 0.06; Ratings: AEC-Q200; Resistance: 100 mΩ; Series: RL; Size / Dimension: 0.122" L x 0.102" W (3.10mm x 2.60mm); Supplier 1: DigiKey; Supplier Device Package: 1210; Supplier Part Number 1: YAG5712CT-ND; Tolerance: ±5%; Value: 100 mΩ
R17 = ERJ-3GEY0R00V: RES SMD 0 OHM JUMPER 1/10W 0603; Manufacturer: Panasonic Electronic Components; Database Table Name: Resistors - Surface Mount - 0603; Power (Watts): 0.1W, 1/10W; Component Kind: Standard; ComponentLink1Description: Datasheet; ComponentLink1URL: http://industrial.panasonic.com/www-cgi/jvcr13pz.cgi?E+PZ+3+AOA0001+ERJ3GEY0R00V+7+WW; ComponentLink2Description: DigiKey Link; ComponentLink2URL: https://www.digikey.com/en/products/detail/panasonic-electronic-components/ERJ-3GEY0R00V/135656; Composition: Thick Film; Device Package: 0603 (1608 Metric); Features: Automotive AEC-Q200; Height - Seated (Max): 0.022" (0.55mm); LastUpdated: 9.02.2024 20:42:26; Library Name: Celestial Altium Library - altium_library.DbLib; Lifecycle Status: Active; Minimum Order: 1; Number of Terminations: 2; Operating Temperature: -55°C ~ 155°C; Package / Case: 0603 (1608 Metric); Packaging: CutTape; Part Number: ERJ-3GEY0R00V; Part Status: Active; PartId: 22603; Price: 0.01; Ratings: AEC-Q200; Resistance: 0 Ohms; Series: ERJ-3G; Size / Dimension: 0.063" L x 0.031" W (1.60mm x 0.80mm); Supplier 1: DigiKey; Supplier Device Package: 0603; Supplier Part Number 1: P0.0GCT-ND; Tolerance: Jumper; Value: 0 Ohms
R18 R22 = CRCW06032K00FKEA: RES SMD 2K OHM 1% 1/10W 0603; Manufacturer: Vishay Dale; Database Table Name: Resistors - Surface Mount - 0603; Power (Watts): 0.1W, 1/10W; Temperature Coefficient: ±100ppm/°C; Component Kind: Standard; ComponentLink1Description: Datasheet; ComponentLink1URL: http://www.vishay.com/docs/20035/dcrcwe3.pdf; ComponentLink2Description: DigiKey Link; ComponentLink2URL: https://www.digikey.com/en/products/detail/vishay-dale/CRCW06032K00FKEA/1174700; Composition: Thick Film; Device Package: 0603 (1608 Metric); Features: Automotive AEC-Q200; Height - Seated (Max): 0.020" (0.50mm); LastUpdated: 9.02.2024 20:43:05; Library Name: Celestial Altium Library - altium_library.DbLib; Lifecycle Status: Active; Minimum Order: 1; Number of Terminations: 2; Operating Temperature: -55°C ~ 155°C; Package / Case: 0603 (1608 Metric); Packaging: CutTape; Part Number: CRCW06032K00FKEA; Part Status: Active; PartId: 12693; Price: 0.01; Ratings: AEC-Q200; Resistance: 2 kΩ; Series: CRCW; Size / Dimension: 0.061" L x 0.033" W (1.55mm x 0.85mm); Supplier 1: DigiKey; Supplier Device Package: 0603; Supplier Part Number 1: 541-2.00KHCT-ND; Tolerance: ±1%; Value: 2 kΩ
R21 = CRCW0603499RFKEA: RES SMD 499 OHM 1% 1/10W 0603; Manufacturer: Vishay Dale; Database Table Name: Resistors - Surface Mount - 0603; Power (Watts): 0.1W, 1/10W; Temperature Coefficient: ±100ppm/°C; Component Kind: Standard; ComponentLink1Description: Datasheet; ComponentLink1URL: http://www.vishay.com/docs/20035/dcrcwe3.pdf; ComponentLink2Description: DigiKey Link; ComponentLink2URL: https://www.digikey.com/en/products/detail/vishay-dale/CRCW0603499RFKEA/1174633; Composition: Thick Film; Device Package: 0603 (1608 Metric); Features: Automotive AEC-Q200; Height - Seated (Max): 0.020" (0.50mm); LastUpdated: 9.02.2024 20:43:05; Library Name: Celestial Altium Library - altium_library.DbLib; Lifecycle Status: Active; Minimum Order: 1; Number of Terminations: 2; Operating Temperature: -55°C ~ 155°C; Package / Case: 0603 (1608 Metric); Packaging: TapeAndReel; Part Number: CRCW0603499RFKEA; Part Status: Active; PartId: 12624; Price: 0.01; Ratings: AEC-Q200; Resistance: 499 Ω; Series: CRCW; Size / Dimension: 0.061" L x 0.033" W (1.55mm x 0.85mm); Supplier 1: DigiKey; Supplier Device Package: 0603; Supplier Part Number 1: 541-499HCT-ND; Tolerance: ±1%; Value: 499 Ω
R23 = CRCW06031K00FKEA: RES SMD 1K OHM 1% 1/10W 0603; Manufacturer: Vishay Dale; Database Table Name: Resistors - Surface Mount - 0603; Power (Watts): 0.1W, 1/10W; Resistance: 1 kOhms; Temperature Coefficient: ±100ppm/°C; Component Kind: Standard; ComponentLink1Description: Datasheet; ComponentLink1URL: http://www.vishay.com/docs/20035/dcrcwe3.pdf; ComponentLink2Description: DigiKey Link; ComponentLink2URL: https://www.digikey.com/en/products/detail/vishay-dale/CRCW06031K00FKEA/1174668; Composition: Thick Film; Device Package: 0603 (1608 Metric); Features: Automotive AEC-Q200; Height - Seated (Max): 0.020" (0.50mm); LastUpdated: 9.02.2024 20:42:10; Library Name: Celestial Altium Library - altium_library.DbLib; Lifecycle Status: Active; Minimum Order: 1; Number of Terminations: 2; Operating Temperature: -55°C ~ 155°C; Package / Case: 0603 (1608 Metric); Packaging: CutTape; Part Number: CRCW06031K00FKEA; Part Status: Active; PartId: 24637; Price: 0.01; Ratings: AEC-Q200; Series: CRCW; Size / Dimension: 0.061" L x 0.033" W (1.55mm x 0.85mm); Supplier 1: DigiKey; Supplier Device Package: 0603; Supplier Part Number 1: 541-1.00KHCT-ND; Tolerance: ±1%; Value: 1 kΩ
R24 = CRCW06032K61FKEA: RES SMD 2.61K OHM 1% 1/10W 0603; Manufacturer: Vishay Dale; Database Table Name: Resistors - Surface Mount - 0603; Power (Watts): 0.1W, 1/10W; Temperature Coefficient: ±100ppm/°C; Component Kind: Standard; ComponentLink1Description: Datasheet; ComponentLink1URL: http://www.vishay.com/docs/20035/dcrcwe3.pdf; ComponentLink2Description: DigiKey Link; ComponentLink2URL: https://www.digikey.com/en/products/detail/vishay-dale/CRCW06032K61FKEA/1174713; Composition: Thick Film; Device Package: 0603 (1608 Metric); Features: Automotive AEC-Q200; Height - Seated (Max): 0.020" (0.50mm); LastUpdated: 9.02.2024 20:43:25; Library Name: Celestial Altium Library - altium_library.DbLib; Lifecycle Status: Active; Minimum Order: 1; Number of Terminations: 2; Operating Temperature: -55°C ~ 155°C; Package / Case: 0603 (1608 Metric); Packaging: TapeAndReel; Part Number: CRCW06032K61FKEA; Part Status: Active; PartId: 7853; Price: 0.01; Ratings: AEC-Q200; Resistance: 2.61 kOhms; Series: CRCW; Size / Dimension: 0.061" L x 0.033" W (1.55mm x 0.85mm); Supplier 1: DigiKey; Supplier Device Package: 0603; Supplier Part Number 1: 541-2.61KHCT-ND; Tolerance: ±1%; Value: 2.61 kOhms
R25 R33 = RNCP0603FTD24K9: RES 24.9K OHM 1% 1/8W 0603; Manufacturer: Stackpole Electronics Inc; Database Table Name: Resistors - Surface Mount - 0603; Power (Watts): 0.125W, 1/8W; Temperature Coefficient: ±100ppm/°C; Component Kind: Standard; ComponentLink1Description: Datasheet; ComponentLink1URL: https://www.seielect.com/catalog/sei-rncp.pdf; ComponentLink2Description: DigiKey Link; ComponentLink2URL: https://www.digikey.com/en/products/detail/stackpole-electronics-inc/RNCP0603FTD24K9/2240152; Composition: Thin Film; Device Package: 0603 (1608 Metric); Features: Anti-Sulfur; Height - Seated (Max): 0.022" (0.55mm); LastUpdated: 9.02.2024 20:43:33; Library Name: Celestial Altium Library - altium_library.DbLib; Lifecycle Status: Active; Minimum Order: 1; Number of Terminations: 2; Operating Temperature: -55°C ~ 155°C; Package / Case: 0603 (1608 Metric); Packaging: TapeAndReel; Part Number: RNCP0603FTD24K9; Part Status: Active; PartId: 5725; Price: 0.01; Resistance: 24.9 kΩ; Series: RNCP; Size / Dimension: 0.061" L x 0.031" W (1.55mm x 0.80mm); Supplier 1: DigiKey; Supplier Device Package: 0603; Supplier Part Number 1: RNCP0603FTD24K9CT-ND; Tolerance: ±1%; Value: 24.9 kΩ
R26 R30 R31 = RCS0603100KFKEA: RES SMD 100K OHM 1% 1/4W 0603; Manufacturer: Vishay Dale; Database Table Name: Resistors - Surface Mount - 0603; Power (Watts): 0.25W, 1/4W; Temperature Coefficient: ±100ppm/°C; Component Kind: Standard; ComponentLink1Description: Datasheet; ComponentLink1URL: http://www.vishay.com/docs/20065/rcse3.pdf; ComponentLink2Description: DigiKey Link; ComponentLink2URL: https://www.digikey.com/en/products/detail/vishay-dale/RCS0603100KFKEA/5866946; Composition: Thick Film; Device Package: 0603 (1608 Metric); Features: Automotive AEC-Q200, Pulse Withstanding; Height - Seated (Max): 0.020" (0.50mm); LastUpdated: 9.02.2024 20:43:35; Library Name: Celestial Altium Library - altium_library.DbLib; Lifecycle Status: Active; Minimum Order: 1; Number of Terminations: 2; Operating Temperature: -55°C ~ 155°C; Package / Case: 0603 (1608 Metric); Packaging: TapeAndReel; Part Number: RCS0603100KFKEA; Part Status: Active; PartId: 5363; Price: 0.01; Ratings: AEC-Q200; Resistance: 100 kΩ; Series: RCS e3; Size / Dimension: 0.061" L x 0.033" W (1.55mm x 0.85mm); Supplier 1: DigiKey; Supplier Device Package: 0603; Supplier Part Number 1: 541-2800-1-ND; Tolerance: ±1%; Value: 100 kΩ
R27 = RMCF0603FT69K8: RES 69.8K OHM 1% 1/10W 0603; Manufacturer: Stackpole Electronics Inc; Database Table Name: Resistors - Surface Mount - 0603; Power (Watts): 0.1W, 1/10W; Temperature Coefficient: ±100ppm/°C; Component Kind: Standard; ComponentLink1Description: Datasheet; ComponentLink1URL: https://www.seielect.com/catalog/sei-rmcf_rmcp.pdf; ComponentLink2Description: DigiKey Link; ComponentLink2URL: https://www.digikey.com/en/products/detail/stackpole-electronics-inc/RMCF0603FT69K8/1714202; Composition: Thick Film; Device Package: 0603 (1608 Metric); Features: Automotive AEC-Q200; Height - Seated (Max): 0.022" (0.55mm); LastUpdated: 9.02.2024 20:42:51; Library Name: Celestial Altium Library - altium_library.DbLib; Lifecycle Status: Active; Minimum Order: 1; Number of Terminations: 2; Operating Temperature: -55°C ~ 155°C; Package / Case: 0603 (1608 Metric); Packaging: CutTape; Part Number: RMCF0603FT69K8; Part Status: Active; PartId: 16385; Price: 0.01; Ratings: AEC-Q200; Resistance: 69.8 kΩ; Series: RMCF; Size / Dimension: 0.061" L x 0.031" W (1.55mm x 0.80mm); Supplier 1: DigiKey; Supplier Device Package: 0603; Supplier Part Number 1: RMCF0603FT69K8CT-ND; Tolerance: ±1%; Value: 69.8 kΩ
R28 = RC0603FR-074K64L: RES 4.64K OHM 1% 1/10W 0603; Manufacturer: Yageo; Database Table Name: Resistors - Surface Mount - 0603; Power (Watts): 0.1W, 1/10W; Temperature Coefficient: ±100ppm/°C; Component Kind: Standard; ComponentLink1Description: Datasheet; ComponentLink1URL: https://www.yageo.com/upload/media/product/productsearch/datasheet/rchip/PYu-RC_Group_51_RoHS_L_10.pdf; ComponentLink2Description: DigiKey Link; ComponentLink2URL: https://www.digikey.com/en/products/detail/yageo/RC0603FR-074K64L/727211; Composition: Thick Film; Device Package: 0603 (1608 Metric); Features: Moisture Resistant; Height - Seated (Max): 0.022" (0.55mm); LastUpdated: 25.07.2024 09:19:27; Library Name: Celestial Altium Library - altium_library.DbLib; Lifecycle Status: Active; Minimum Order: 1; Number of Terminations: 2; Operating Temperature: -55°C ~ 155°C; Package / Case: 0603 (1608 Metric); Packaging: CutTape; Part Number: RC0603FR-074K64L; Part Status: Active; PartId: 12437; Price: 0.01; Resistance: 4.64 kΩ; Series: RC_L; Size / Dimension: 0.063" L x 0.031" W (1.60mm x 0.80mm); Supplier 1: DigiKey; Supplier Device Package: 0603; Supplier Part Number 1: 311-4.64KHRCT-ND; Tolerance: ±1%; Value: 4.64 kΩ
R29 = RC0603FR-0740K2L: RES 40.2K OHM 1% 1/10W 0603; Manufacturer: Yageo; Database Table Name: Resistors - Surface Mount - 0603; Power (Watts): 0.1W, 1/10W; Temperature Coefficient: ±100ppm/°C; Component Kind: Standard; ComponentLink1Description: Datasheet; ComponentLink1URL: https://www.yageo.com/upload/media/product/productsearch/datasheet/rchip/PYu-RC_Group_51_RoHS_L_10.pdf; ComponentLink2Description: DigiKey Link; ComponentLink2URL: https://www.digikey.com/en/products/detail/yageo/RC0603FR-0740K2L/727221; Composition: Thick Film; Device Package: 0603 (1608 Metric); Features: Moisture Resistant; Height - Seated (Max): 0.022" (0.55mm); LastUpdated: 25.07.2024 09:19:28; Library Name: Celestial Altium Library - altium_library.DbLib; Lifecycle Status: Active; Minimum Order: 1; Number of Terminations: 2; Operating Temperature: -55°C ~ 155°C; Package / Case: 0603 (1608 Metric); Packaging: CutTape; Part Number: RC0603FR-0740K2L; Part Status: Active; PartId: 12261; Price: 0.01; Resistance: 40.2 kΩ; Series: RC_L; Size / Dimension: 0.063" L x 0.031" W (1.60mm x 0.80mm); Supplier 1: DigiKey; Supplier Device Package: 0603; Supplier Part Number 1: 311-40.2KHRCT-ND; Tolerance: ±1%; Value: 40.2 kΩ
R32 = RK73H1JTTD63R4F: RES 63.4 OHM 1% 1/8W 0603; Manufacturer: KOA Speer Electronics, Inc.; Database Table Name: Resistors - Surface Mount - 0603; Power (Watts): 0.125W, 1/8W; Temperature Coefficient: ±100ppm/°C; Component Kind: Standard; ComponentLink1Description: Datasheet; ComponentLink1URL: http://www.koaspeer.com/catimages/Products/RK73H/RK73H.pdf; ComponentLink2Description: DigiKey Link; ComponentLink2URL: https://www.digikey.com/en/products/detail/koa-speer-electronics-inc/RK73H1JTTD63R4F/9845379; Composition: Thick Film; Device Package: 0603 (1608 Metric); Features: Automotive AEC-Q200; Height - Seated (Max): 0.022" (0.55mm); LastUpdated: 25.07.2024 09:43:06; Library Name: Celestial Altium Library - altium_library.DbLib; Lifecycle Status: Active; Minimum Order: 1; Number of Terminations: 2; Operating Temperature: -55°C ~ 155°C; Package / Case: 0603 (1608 Metric); Packaging: CutTape; Part Number: RK73H1JTTD63R4F; Part Status: Active; PartId: 13917; Price: 0.01; Ratings: AEC-Q200; Resistance: 63.4 Ω; Series: RK73H; Size / Dimension: 0.063" L x 0.031" W (1.60mm x 0.80mm); Supplier 1: DigiKey; Supplier Device Package: 0603; Supplier Part Number 1: 2019-RK73H1JTTD63R4FCT-ND; Tolerance: ±1%; Value: 63.4 Ω
U4 = HMHA2801A: Optoisolator Transistor Output 3750Vrms 1 Channel 4-Mini-Flat; Manufacturer_Name: onsemi; Geometry.Height: 2.39mm; Arrow Part Number: HMHA2801A; Arrow Price/Stock: https://www.arrow.com/en/products/hmha2801a/on-semiconductor?utm_currency=USD&region=nac; Component Kind: Standard; Datasheet Link: https://www.digikey.ph/en/products/detail/onsemi/HMHA2801A/1052178; Library Name: SchLib.SchLib; Manufacturer_Part_Number: HMHA2801A; Mouser Part Number: 512-HMHA2801A; Mouser Price/Stock: https://www.mouser.co.uk/ProductDetail/onsemi-Fairchild/HMHA2801A?qs=kFka3UPfw%2Fr%2FqIBcKba8Jg%3D%3D
U5 = CMP-0390-00071-2: High Power / High Efficiency PoE Interface and DC / DC Controller, -40 to 125 degC, 20-pin SOP (PW20), Green (RoHS & no Sb/Br); Manufacturer: Texas Instruments; Auxiliary Power Rails Supported: 24V-57V; Auxiliary/Local Power Support: Programmable; Driven/Active Clamp (Second Gate Drive): Yes; Duty Cycle(%): 78; Fault Response: Auto Retry; PD Power Level: 25W; PoE Current Limit(Min)(mA): 850; PoE I/F & DC DC Control: TRUE; PoE Inrush Limit(Typ)(mA): 140; PoE Standards Supported: 802.3at type1, 2; rDS(on) per FET(Typ)(mOhms): 430; SubFamily: Powered Device; Switching Frequency: Synchronizable and Programmable; UVLO: Unified; Code_JEDEC: MO-153; Component Kind: Standard; ComponentLink1Description: Manufacturer URL; ComponentLink1URL: http://www.ti.com/; ComponentLink2Description: Datasheet; ComponentLink2URL: http://www.ti.com/general/docs/lit/getliterature.tsp?genericPartNumber=TPS23754&fileType=pdf; ComponentLink3Description: Package Specification; ComponentLink3URL: http://www.ti.com/litv/pdf/mhts001g; DatasheetVersion: SLVS885F; Eco-Plan: Green (RoHS & no Sb/Br); Library Name: Altium Content Vault; Mounting Technology: Surface Mount; PackageDescription: 20-Pin PowerPAD Plastic Small Outline, Body 6.5 x 4.4 mm,0.65 mm Pitch; PackageReference: PWP0020D; PackageVersion: 4073225-4/1; PartNumber: TPS23754PWP
U6 = CMP-0366-00617-2: Adjustable Precision Shunt Regulator, 34 ppm / degC, 100 mA, -40 to 85 degC, 5-pin SOT-23 (DBV), Green (RoHS & no Sb/Br); Manufacturer: Texas Instruments; Initial Accuracy @ 25/deg C(%): 1; Iout/Iz(Max)(mA): 100; Min Iz for Regulation(uA): 600; Rating: Catalog; SubFamily: Shunt Voltage Reference; Temp Coeff(Max)(ppm/ degree C): 92; Temp Coeff(Typ)(ppm/ degree C): 34; VO Adj(Max)(V): 36; VO Adj(Min)(V): 2.495; Code_JEDEC: MO-178; Component Kind: Standard; ComponentLink1Description: Manufacturer URL; ComponentLink1URL: http://www.ti.com/; ComponentLink2Description: Datasheet; ComponentLink2URL: http://www.ti.com/general/docs/lit/getliterature.tsp?genericPartNumber=TL431A&fileType=pdf; ComponentLink3Description: Package Specification; ComponentLink3URL: http://www.ti.com/litv/pdf/mpds018i; DatasheetVersion: SLVS543M; Eco-Plan: Green (RoHS & no Sb/Br); Library Name: Altium Content Vault; Mounting Technology: Surface Mount; PackageDescription: 5-Pin Plastic Small Outline, Body 1.60 Ã— 2.90 mm, Pitch 0.95 mm; PackageReference: DBV0005A; PackageVersion: 4073253-4/K; PartNumber: TL431AIDBVT
U7 = PC357N4J000F: Transistor Output Optocouplers 300-600% CTR ranked PC357NJ0000F; Manufacturer_Name: Sharp Microelectronics; Geometry.Height: 3mm; Arrow Part Number: PC357N4J000F; Arrow Price/Stock: https://www.arrow.com/en/products/pc357n4j000f/sharp?utm_currency=USD&region=europe; Component Kind: Standard; Datasheet Link: https://datasheet.datasheetarchive.com/originals/distributors/Datasheets_SAMA/8df7351162fb494e02ad9abf95b0d019.pdf; Library Name: SchLib.SchLib; Manufacturer_Part_Number: PC357N4J000F; Mouser Part Number: 852-PC357N4J000F; Mouser Price/Stock: https://www.mouser.co.uk/ProductDetail/Sharp-Microelectronics/PC357N4J000F?qs=UtH%252B%2FzslHwoprMr%2FFF3%252BjA%3D%3D
